# Altium schematic sheet RS485  ADDRESS  RS232 .SchDoc
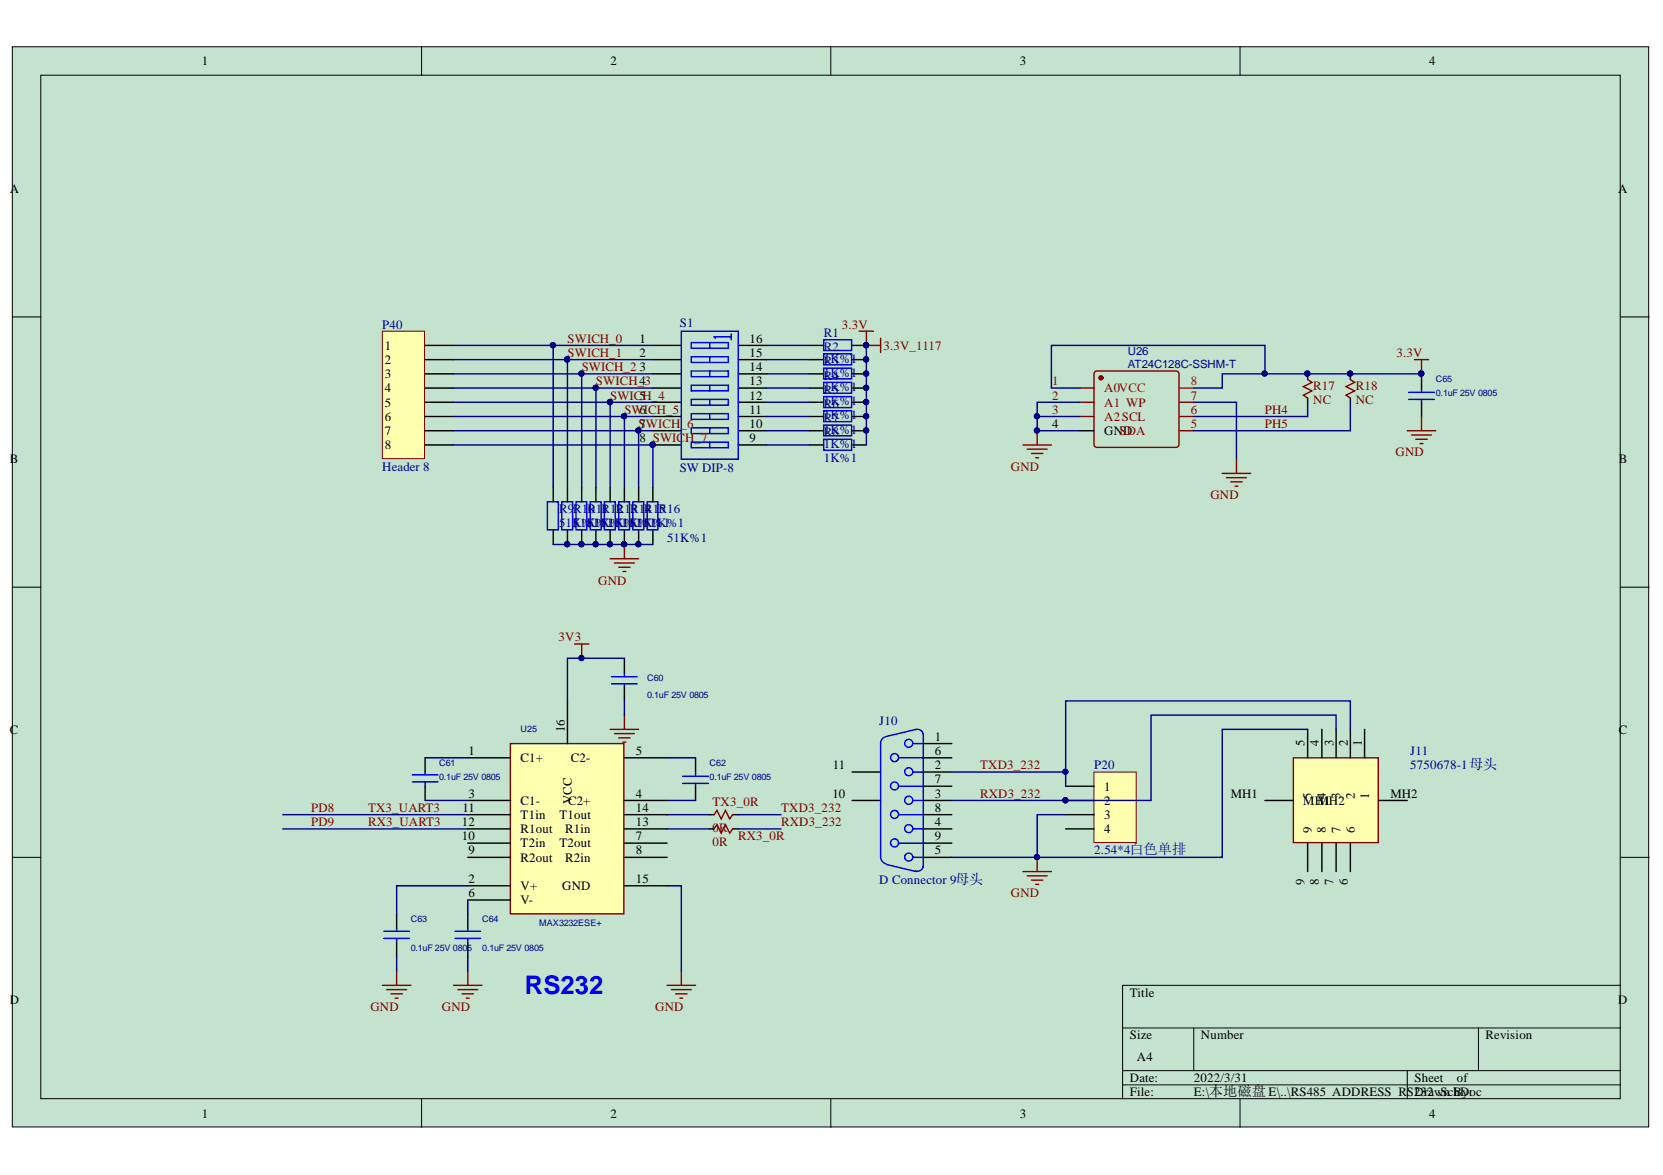
[diagram p0: RS485  ADDRESS  RS232 .SchDoc]

## Parts
C60  0.1uF 25V 0805  [CAP0805]
C61  0.1uF 25V 0805  [CAP0805]
C62  0.1uF 25V 0805  [CAP0805]
C63  0.1uF 25V 0805  [CAP0805]
C64  0.1uF 25V 0805  [CAP0805]
C65  0.1uF 25V 0805  [CAP0805]
J10  D Connector 9母头  [DB9]
J11  5750678-1 母头  [57506781]
P20  2.54*4白色单排  [CON4 2.54*4 单排白座]
P40  Header 8  [HDR1X8]
R1  1K%1  [R0805]
R2  1K%1  [R0805]
R3  1K%1  [R0805]
R4  1K%1  [R0805]
R5  1K%1  [R0805]
R6  1K%1  [R0805]
R7  1K%1  [R0805]
R8  1K%1  [R0805]
R9  51K%1  [R0805]
R10  51K%1  [R0805]
R11  51K%1  [R0805]
R12  51K%1  [R0805]
R13  51K%1  [R0805]
R14  51K%1  [R0805]
R15  51K%1  [R0805]
R16  51K%1  [R0805]
R17  NC  [R0805]
R18  NC  [R0805]
RX3_0R  0R  [R0805]
S1  SW DIP-8  [SWITCH_16]
TX3_0R  0R  [R0805]
U25  MAX3232ESE+  [SOP-16_L10.3-W5.4-P1.27-LS7.8-BL]
U26  AT24C128C-SSHM-T  [SO8]

## Nets
3.3V: C65.2 R1.1 R2.1 R3.1 R4.1 R5.1 R6.1 R7.1 R8.1 R17.2 R18.2 U26.1(A0) U26.8
3V3: C60.2 U25.16
GND: C60.1 C63.2 C64.2 C65.1 J10.5 J11.5 P20.3 R9.2 R10.2 R11.2 R12.2 R13.2 R14.2 R15.2 R16.2 U25.15 U26.2(A1) U26.3(A2_SCL) U26.4(GND) U26.7
PD8: U25.11(T1in)
PD9: U25.12(R1out)
PH4: R17.1 U26.6
PH5: R18.1 U26.5
RXD3_232: J10.3 J11.3 P20.2 RX3_0R.2
SWICH_0: P40.1 R9.1 S1.1
SWICH_1: P40.2 R10.1 S1.2
SWICH_2: P40.3 R11.1 S1.3
SWICH_3: P40.4 R12.1 S1.4
SWICH_4: P40.5 R13.1 S1.5
SWICH_5: P40.6 R14.1 S1.6
SWICH_6: P40.7 R15.1 S1.7
SWICH_7: P40.8 R16.1 S1.8
TXD3_232: J10.2 J11.2 P20.1 TX3_0R.2
NetC61_1: C61.1 U25.1(C1+)
NetC61_2: C61.2 U25.3(C1-)
NetC62_1: C62.1 U25.4
NetC62_2: C62.2 U25.5
NetC63_1: C63.1 U25.2(V+)
NetC64_1: C64.1 U25.6(V-)
NetR1_2: R1.2 S1.16
NetR2_2: R2.2 S1.15
NetR3_2: R3.2 S1.14
NetR4_2: R4.2 S1.13
NetR5_2: R5.2 S1.12
NetR6_2: R6.2 S1.11
NetR7_2: R7.2 S1.10
NetR8_2: R8.2 S1.9
NetRX3_0R_1: RX3_0R.1 U25.13
NetTX3_0R_1: TX3_0R.1 U25.14

Not connected: J10 1 4 6 7 8 9 10 11; J11 1 4 6 7 8 9 MH1 MH2; P20 4; U25 7 8 9(R2out) 10(T2in)

## Library data of the parts
C60-C65 = CAPACITOR NON-POL: Component Kind: Standard
J10 = D Connector 9: Receptacle Assembly, 9 Position, Right Angle; PackageDocument: O; Positions: 9; Component Kind: Standard; DatasheetDocument: 1-Sep-1999; Gender: Receptacle; LatestRevisionDate: 17-Jul-2002; LatestRevisionNote: Re-released for DXP Platform.; Library Name: Miscellaneous Connectors.IntLib; Orientation: Right Angle; PackageReference: 788750; Published: 15-Jun-2001; Signal Integrity: Connector; Publisher: Altium Limited
J11 = 5750678-1: Connector; Manufacturer_Name: TE Connectivity; Component Kind: Standard; Datasheet Link: https://componentsearchengine.com//5750678-1.pdf; Height: 12.93mm; Manufacturer_Part_Number: 5750678-1; Mouser2 Part Number: 571-5750678-1; Mouser2 Price/Stock: https://www.mouser.com/Search/Refine.aspx?Keyword=571-5750678-1
P20 = Header 4: Header, 4-Pin; Component Kind: Standard; LatestRevisionDate: 17-Jul-2002; LatestRevisionNote: Re-released for DXP Platform.; Library Name: Miscellaneous Connectors.IntLib; Signal Integrity: Connector; Publisher: Altium Limited
P40 = Header 8: Header, 8-Pin; Component Kind: Standard; LatestRevisionDate: 17-Jul-2002; LatestRevisionNote: Re-released for DXP Platform.; Library Name: Miscellaneous Connectors.IntLib; Signal Integrity: Connector; Publisher: Altium Limited
R1-R16 = Res2: Resistor; Component Kind: Standard; Published: 8-Jun-2000; Revision: July-2002: Re-released for DXP Platform.; Publisher: Altium Limited
R17 R18 RX3_0R TX3_0R = RESISTOR: Generic Resistor; Value: 4.7K; Component Kind: Standard; Library Name: Digilent.IntLib
S1 = SW DIP-8: DIP Switch, 8 Position, SPST; Component Kind: Standard; LatestRevisionDate: 17-Jul-2002; LatestRevisionNote: Re-released for DXP Platform.; Library Name: Miscellaneous Devices.IntLib; PackageDescription: DIP Switch; 16 Leads; PackageReference: DIP_SW_8WAY_SMD; Published: 24-Mar-1999; Simulation: dpsw8; Publisher: Altium Limited
U25 = MAX232A_0: Manufacturer: MAXIM; Manufacturer PartNumber: MAX3232CUE; Remark: RS232; Component Kind: Standard
U26 = AT24C128C-SSHM-T: BOM_Manufacturer: MICROCHIP; BOM_JLCPCB Part Class: Extended Part; BOM_Manufacturer Part: AT24C128C-SSHM-T; BOM_Supplier: LCSC; BOM_Supplier Part: C6478; Component Kind: Standard; spicePre: U; spiceSymbolName: AT24C128C-SSHM-T
